# Altium schematic sheet BB1_P9.SchDoc
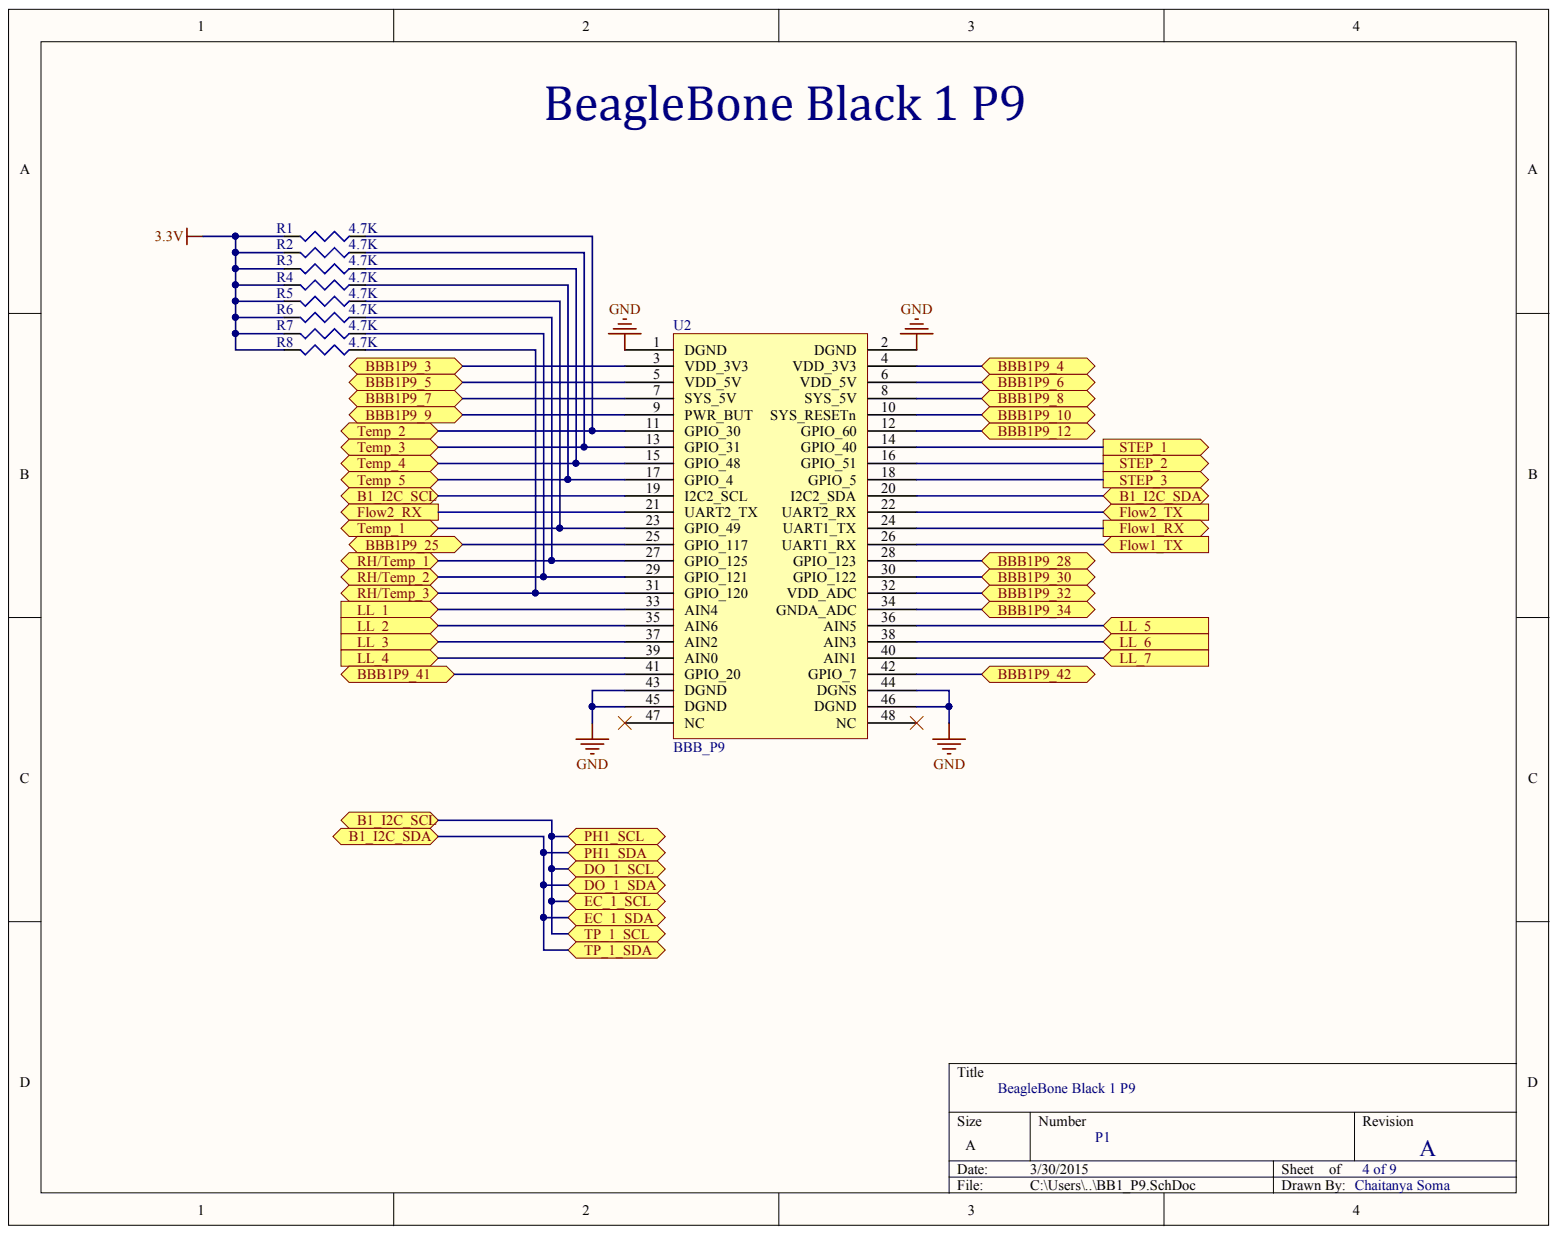
[diagram p0: BB1_P9.SchDoc]

## Parts
R1  value 4.7K  [J1-0603]  (hidden comment: Res3)
R2  value 4.7K  [J1-0603]  (hidden comment: Res3)
R3  value 4.7K  [J1-0603]  (hidden comment: Res3)
R4  value 4.7K  [J1-0603]  (hidden comment: Res3)
R5  value 4.7K  [J1-0603]  (hidden comment: Res3)
R6  value 4.7K  [J1-0603]  (hidden comment: Res3)
R7  value 4.7K  [J1-0603]  (hidden comment: Res3)
R8  value 4.7K  [J1-0603]  (hidden comment: Res3)
U2  BBB_P9  [BeagleBoneBlackP9]

## Ports
B1_I2C_SCL, B1_I2C_SDA, BBB1P9_3, BBB1P9_4, BBB1P9_5, BBB1P9_6, BBB1P9_7, BBB1P9_8, BBB1P9_9, BBB1P9_10, BBB1P9_12, BBB1P9_25, BBB1P9_28, BBB1P9_30, BBB1P9_32, BBB1P9_34, BBB1P9_41, BBB1P9_42, DO_1_SCL, DO_1_SDA, EC_1_SCL, EC_1_SDA, FLOW1_RX, FLOW1_TX, FLOW2_RX, FLOW2_TX, LL_1, LL_2, LL_3, LL_4, LL_5, LL_6, LL_7, PH1_SCL, PH1_SDA, RH/TEMP_1, RH/TEMP_2, RH/TEMP_3, STEP_1, STEP_2, STEP_3, TEMP_1, TEMP_2, TEMP_3, TEMP_4, TEMP_5, TP_1_SCL, TP_1_SDA

## Nets
3.3V: R1.2 R2.2 R3.2 R4.2 R5.2 R6.2 R7.2 R8.2
GND: U2.1(DGND) U2.2(DGND) U2.43(DGND) U2.44(DGNS) U2.45(DGND) U2.46(DGND)
B1_I2C_SCL: U2.19(I2C2_SCL) ; port B1_I2C_SCL, port DO_1_SCL, port EC_1_SCL, port PH1_SCL, port TP_1_SCL
B1_I2C_SDA: U2.20(I2C2_SDA) ; port B1_I2C_SDA, port DO_1_SDA, port EC_1_SDA, port PH1_SDA, port TP_1_SDA
BBB1P9_3: U2.3(VDD_3V3) ; port BBB1P9_3
BBB1P9_4: U2.4(VDD_3V3) ; port BBB1P9_4
BBB1P9_5: U2.5(VDD_5V) ; port BBB1P9_5
BBB1P9_6: U2.6(VDD_5V) ; port BBB1P9_6
BBB1P9_7: U2.7(SYS_5V) ; port BBB1P9_7
BBB1P9_8: U2.8(SYS_5V) ; port BBB1P9_8
BBB1P9_9: U2.9(PWR_BUT) ; port BBB1P9_9
BBB1P9_10: U2.10(SYS_RESETn) ; port BBB1P9_10
BBB1P9_12: U2.12(GPIO_60) ; port BBB1P9_12
BBB1P9_25: U2.25(GPIO_117) ; port BBB1P9_25
BBB1P9_28: U2.28(GPIO_123) ; port BBB1P9_28
BBB1P9_30: U2.30(GPIO_122) ; port BBB1P9_30
BBB1P9_32: U2.32(VDD_ADC) ; port BBB1P9_32
BBB1P9_34: U2.34(GNDA_ADC) ; port BBB1P9_34
BBB1P9_41: U2.41(GPIO_20) ; port BBB1P9_41
BBB1P9_42: U2.42(GPIO_7) ; port BBB1P9_42
LL_1: U2.33(AIN4) ; port LL_1
LL_2: U2.35(AIN6) ; port LL_2
LL_3: U2.37(AIN2) ; port LL_3
LL_4: U2.39(AIN0) ; port LL_4
LL_5: U2.36(AIN5) ; port LL_5
LL_6: U2.38(AIN3) ; port LL_6
LL_7: U2.40(AIN1) ; port LL_7
STEP_1: U2.14(GPIO_40) ; port STEP_1
STEP_2: U2.16(GPIO_51) ; port STEP_2
STEP_3: U2.18(GPIO_5) ; port STEP_3
NetR1_1: R1.1 U2.11(GPIO_30) ; port TEMP_2
NetR2_1: R2.1 U2.13(GPIO_31) ; port TEMP_3
NetR3_1: R3.1 U2.15(GPIO_48) ; port TEMP_4
NetR4_1: R4.1 U2.17(GPIO_4) ; port TEMP_5
NetR5_1: R5.1 U2.23(GPIO_49) ; port TEMP_1
NetR6_1: R6.1 U2.27(GPIO_125) ; port RH/TEMP_1
NetR7_1: R7.1 U2.29(GPIO_121) ; port RH/TEMP_2
NetR8_1: R8.1 U2.31(GPIO_120) ; port RH/TEMP_3
NetU2_21: U2.21(UART2_TX) ; port FLOW2_RX
NetU2_22: U2.22(UART2_RX) ; port FLOW2_TX
NetU2_24: U2.24(UART1_TX) ; port FLOW1_RX
NetU2_26: U2.26(UART1_RX) ; port FLOW1_TX

Not connected: U2 47(NC) 48(NC)

## Library data of the parts
R1-R8 = Res3: Resistor; Component Cost: 0.29000; Component Link Descriptions: RES SMD 4.7K OHM 1% 1/4W 0603; Component Kind: Standard; Component Link URL: http://www.digikey.com/product-detail/en/ERJ-PA3F4701V/P4.7KBYCT-ND/5036195; Digikey PN: P4.7KBYCT-ND; LatestRevisionDate: 29-May-2009; LatestRevisionNote: IPC-7351 Footprint Added.; Library Name: Miscellaneous Devices.IntLib; PackageDescription: Chip Resistor; PackageReference: J1-0603; Published: 8-Jun-2000; Signal Integrity: Res; Simulation: RESISTOR; Publisher: Altium Limited
U2 = BBB_P9: Beagle Bone Black P9; Component Cost: 2.81000; Component Link Descriptions: CONN HEADER FMAL 46PS.1" DL GOLD; Component Kind: Standard; Component Link URL: http://www.digikey.com/product-detail/en/PPPC232LFBN-RC/S7126-ND/810262; Digikey PN: S7126-ND; Library Name: Power.SchLib
